# Altium schematic sheet MM-08 FPS-400.SchDoc("MM-08 FPS-400")
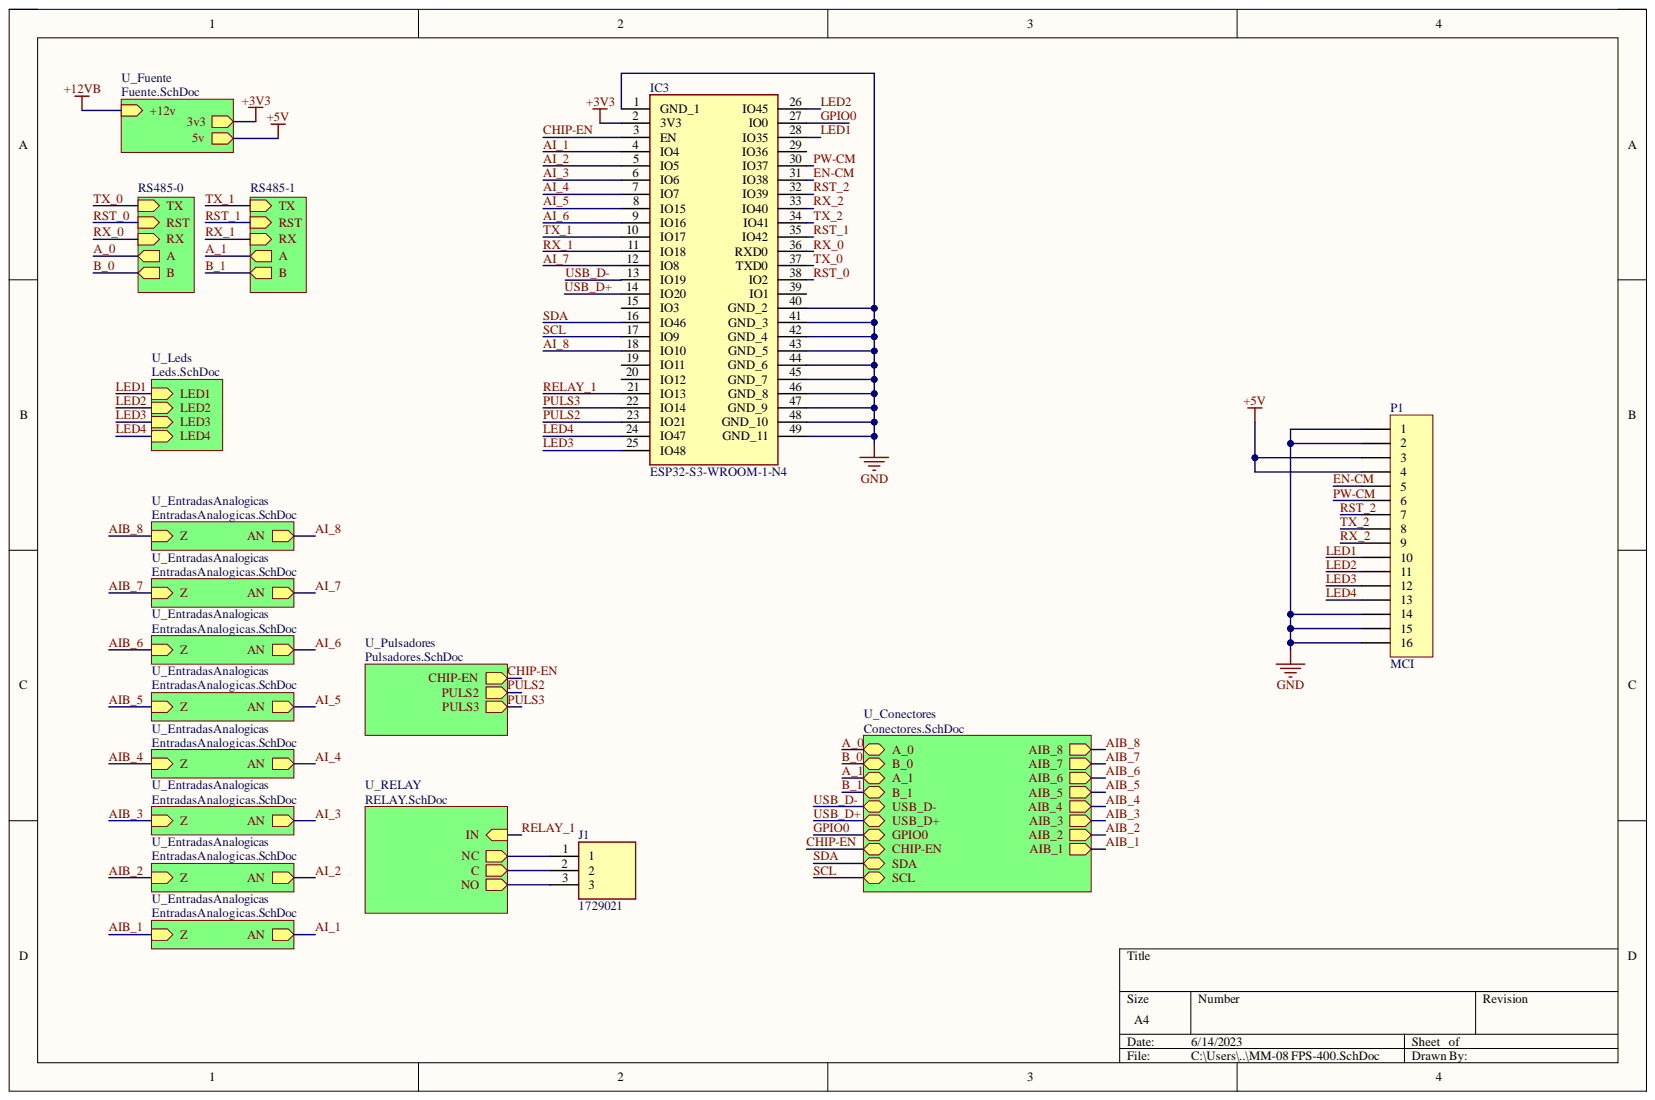
[diagram p0: MM-08 FPS-400.SchDoc]

## Parts
IC3  ESP32-S3-WROOM-1-N4  [Model Name]
J1  1729021  [1729021]
P1  MCI  [HDR1X16]

## Nets
+3V3: IC3.2(3V3)
+5V: P1.3 P1.4
GND: IC3.1(GND_1) IC3.40(GND_2) IC3.41(GND_3) IC3.42(GND_4) IC3.43(GND_5) IC3.44(GND_6) IC3.45(GND_7) IC3.46(GND_8) IC3.47(GND_9) IC3.48(GND_10) IC3.49(GND_11) P1.1 P1.2 P1.14 P1.15 P1.16
AI_1: IC3.4(IO4)
AI_2: IC3.5(IO5)
AI_3: IC3.6(IO6)
AI_4: IC3.7(IO7)
AI_5: IC3.8(IO15)
AI_6: IC3.9(IO16)
AI_7: IC3.12(IO8)
AI_8: IC3.18(IO10)
CHIP-EN: IC3.3(EN)
EN-CM: IC3.31(IO38) P1.5
GPIO0: IC3.27(IO0)
LED1: IC3.28(IO35) P1.10
LED2: IC3.26(IO45) P1.11
LED3: IC3.25(IO48) P1.12
LED4: IC3.24(IO47) P1.13
PULS2: IC3.23(IO21)
PULS3: IC3.22(IO14)
PW-CM: IC3.30(IO37) P1.6
RELAY_1: IC3.21(IO13)
RST_0: IC3.38(IO2)
RST_1: IC3.35(IO42)
RST_2: IC3.32(IO39) P1.7
RX_0: IC3.36(RXD0)
RX_1: IC3.11(IO18)
RX_2: IC3.33(IO40) P1.9
SCL: IC3.17(IO9)
SDA: IC3.16(IO46)
TX_0: IC3.37(TXD0)
TX_1: IC3.10(IO17)
TX_2: IC3.34(IO41) P1.8
USB_D+: IC3.14(IO20)
USB_D-: IC3.13(IO19)
NetIC3_15: IC3.15(IO3)
NetIC3_19: IC3.19(IO11)
NetIC3_20: IC3.20(IO12)
NetIC3_29: IC3.29(IO36)
NetIC3_39: IC3.39(IO1)
NetJ1_1: J1.1
NetJ1_2: J1.2
NetJ1_3: J1.3
+12VB:
A_0:
A_1:
AIB_1:
AIB_2:
AIB_3:
AIB_4:
AIB_5:
AIB_6:
AIB_7:
AIB_8:
B_0:
B_1:

## Library data of the parts
IC3 = ESP32-S3-WROOM-1-N4: Integrated Circuit; Manufacturer_Name: Espressif Systems; Component Kind: Standard; Datasheet Link: https://www.espressif.com/sites/default/files/documentation/esp32-s3-wroom-1_wroom-1u_datasheet_en.pdf; Height: 3.25mm; Manufacturer_Part_Number: ESP32-S3-WROOM-1-N4; Mouser Part Number: 356-ESP32-S3WROOM1N4; Mouser Price/Stock: https://www.mouser.co.uk/ProductDetail/Espressif-Systems/ESP32-S3-WROOM-1-N4?qs=Li%252BoUPsLEnsO%2FkiJOUK0FQ%3D%3D; Library Name: CPU FPS-400 2022.IntLib
J1 = 1729021: Connector; Manufacturer_Name: Phoenix Contact; Arrow Part Number: 1729021; Arrow Price/Stock: https://www.arrow.com/en/products/1729021/phoenix-contact?region=nac; Component Kind: Standard; Datasheet Link: http://www.phoenixcontact.com/de/produkte/1729021/pdf; Height: 10.15mm; Manufacturer_Part_Number: 1729021; Mouser Part Number: 651-1729021; Mouser Price/Stock: https://www.mouser.co.uk/ProductDetail/Phoenix-Contact/1729021?qs=zFpBqiaOpnwhKtz3PKBNAQ%3D%3D
P1 = Header 16: Header, 16-Pin; Component Kind: Standard; LatestRevisionDate: 17-Jul-2002; LatestRevisionNote: Re-released for DXP Platform.; Library Name: Miscellaneous Connectors.IntLib; Signal Integrity: Connector; Publisher: Altium Limited
